# Altium schematic sheet FGM410_485.SchDoc
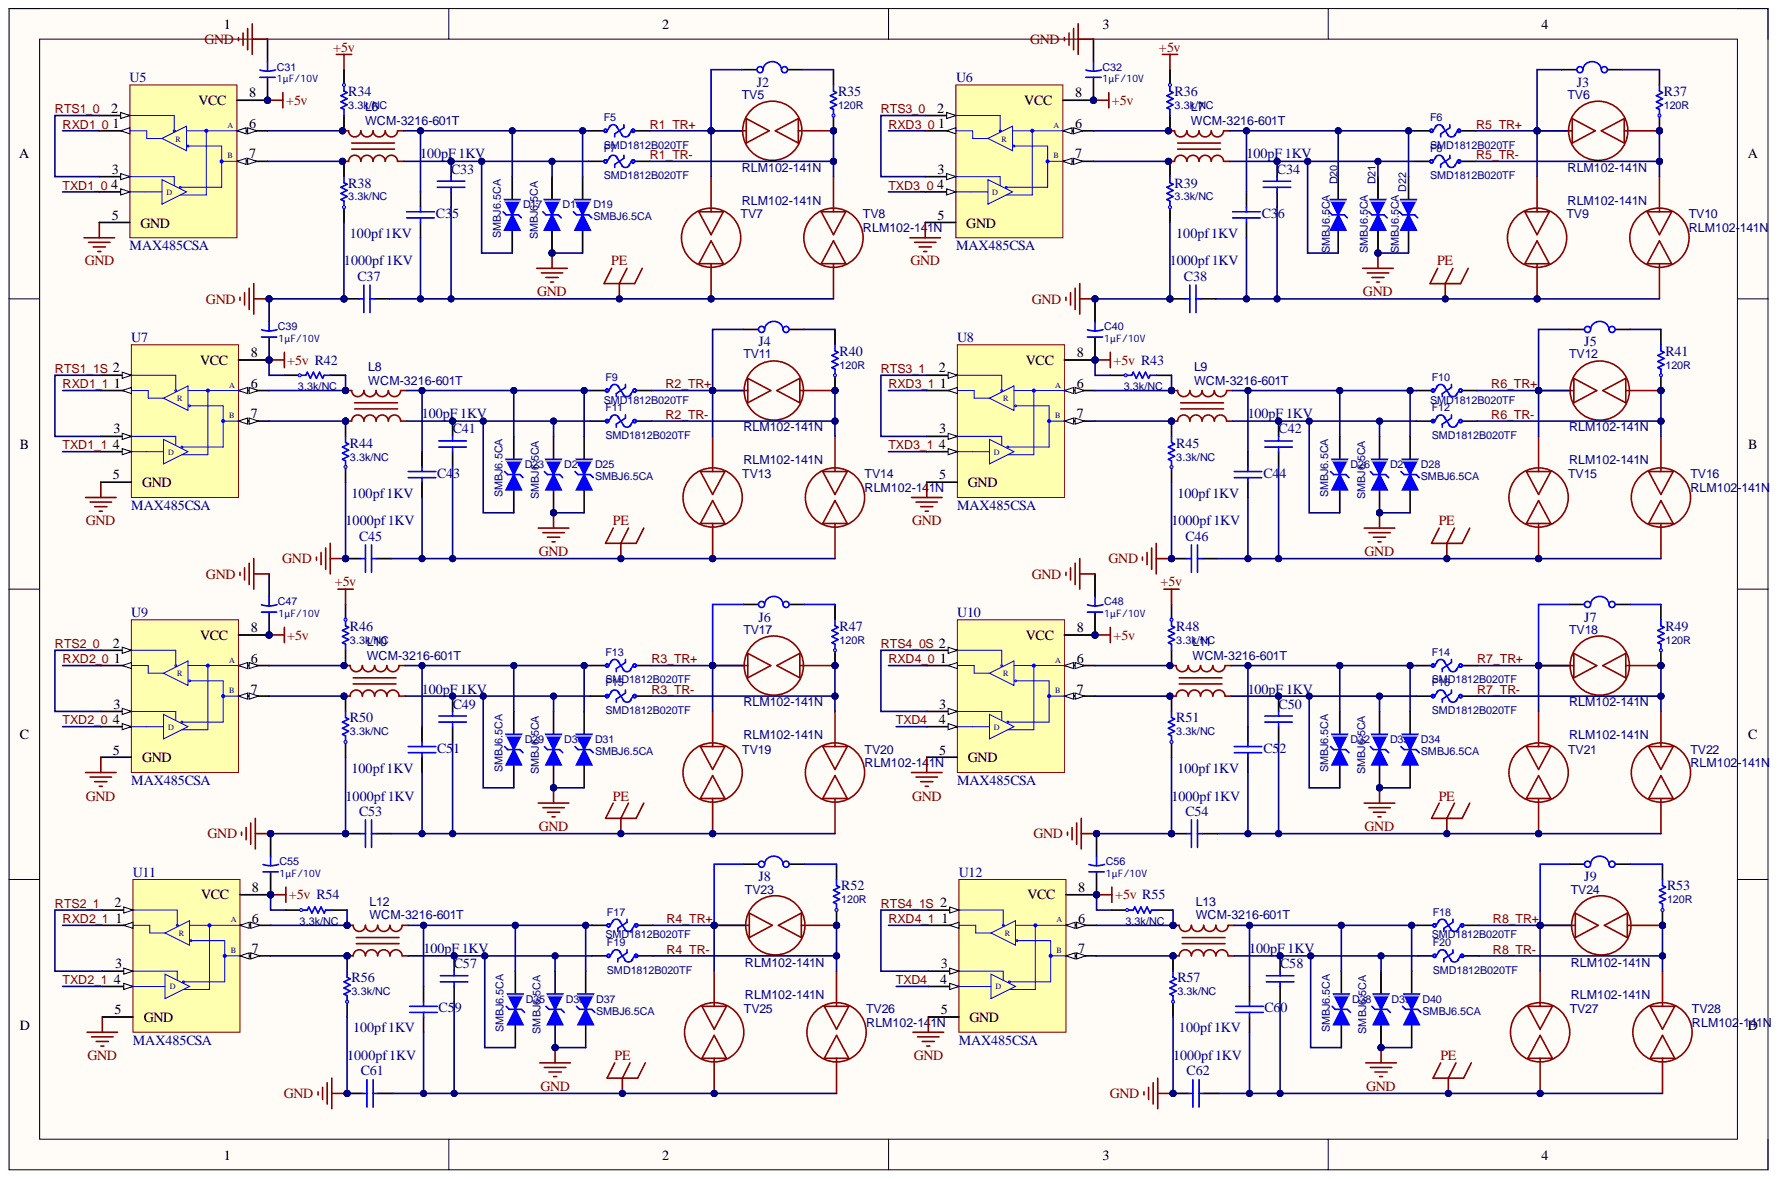
[diagram p0: FGM410_485.SchDoc]

## Parts
C31  1μF/10V  [0603_C]
C32  1μF/10V  [0603_C]
C33  100pF 1KV  [C1206]
C34  100pF 1KV  [C1206]
C35  100pf 1KV  [C1206]
C36  100pf 1KV  [C1206]
C37  1000pf 1KV  [C1206]
C38  1000pf 1KV  [C1206]
C39  1μF/10V  [0603_C]
C40  1μF/10V  [0603_C]
C41  100pF 1KV  [C1206]
C42  100pF 1KV  [C1206]
C43  100pf 1KV  [C1206]
C44  100pf 1KV  [C1206]
C45  1000pf 1KV  [C1206]
C46  1000pf 1KV  [C1206]
C47  1μF/10V  [0603_C]
C48  1μF/10V  [0603_C]
C49  100pF 1KV  [C1206]
C50  100pF 1KV  [C1206]
C51  100pf 1KV  [C1206]
C52  100pf 1KV  [C1206]
C53  1000pf 1KV  [C1206]
C54  1000pf 1KV  [C1206]
C55  1μF/10V  [0603_C]
C56  1μF/10V  [0603_C]
C57  100pF 1KV  [C1206]
C58  100pF 1KV  [C1206]
C59  100pf 1KV  [C1206]
C60  100pf 1KV  [C1206]
C61  1000pf 1KV  [C1206]
C62  1000pf 1KV  [C1206]
D17  SMBJ6.5CA  [SMB]
D18  SMBJ6.5CA  [SMB]
D19  SMBJ6.5CA  [SMB]
D20  SMBJ6.5CA  [SMB]
D21  SMBJ6.5CA  [SMB]
D22  SMBJ6.5CA  [SMB]
D23  SMBJ6.5CA  [SMB]
D24  SMBJ6.5CA  [SMB]
D25  SMBJ6.5CA  [SMB]
D26  SMBJ6.5CA  [SMB]
D27  SMBJ6.5CA  [SMB]
D28  SMBJ6.5CA  [SMB]
D29  SMBJ6.5CA  [SMB]
D30  SMBJ6.5CA  [SMB]
D31  SMBJ6.5CA  [SMB]
D32  SMBJ6.5CA  [SMB]
D33  SMBJ6.5CA  [SMB]
D34  SMBJ6.5CA  [SMB]
D35  SMBJ6.5CA  [SMB]
D36  SMBJ6.5CA  [SMB]
D37  SMBJ6.5CA  [SMB]
D38  SMBJ6.5CA  [SMB]
D39  SMBJ6.5CA  [SMB]
D40  SMBJ6.5CA  [SMB]
F5  SMD1812B020TF  [F1812]
F6  SMD1812B020TF  [F1812]
F7  SMD1812B020TF  [F1812]
F8  SMD1812B020TF  [F1812]
F9  SMD1812B020TF  [F1812]
F10  SMD1812B020TF  [F1812]
F11  SMD1812B020TF  [F1812]
F12  SMD1812B020TF  [F1812]
F13  SMD1812B020TF  [F1812]
F14  SMD1812B020TF  [F1812]
F15  SMD1812B020TF  [F1812]
F16  SMD1812B020TF  [F1812]
F17  SMD1812B020TF  [F1812]
F18  SMD1812B020TF  [F1812]
F19  SMD1812B020TF  [F1812]
F20  SMD1812B020TF  [F1812]
J2  JP  [SIP2-2.54]  (value not drawn)
J3  JP  [SIP2-2.54]  (value not drawn)
J4  JP  [SIP2-2.54]  (value not drawn)
J5  JP  [SIP2-2.54]  (value not drawn)
J6  JP  [SIP2-2.54]  (value not drawn)
J7  JP  [SIP2-2.54]  (value not drawn)
J8  JP  [SIP2-2.54]  (value not drawn)
J9  JP  [SIP2-2.54]  (value not drawn)
L6  WCM-3216-601T  [FILTER-SMD_4P-L3.2-W1.6-BL]
L7  WCM-3216-601T  [FILTER-SMD_4P-L3.2-W1.6-BL]
L8  WCM-3216-601T  [FILTER-SMD_4P-L3.2-W1.6-BL]
L9  WCM-3216-601T  [FILTER-SMD_4P-L3.2-W1.6-BL]
L10  WCM-3216-601T  [FILTER-SMD_4P-L3.2-W1.6-BL]
L11  WCM-3216-601T  [FILTER-SMD_4P-L3.2-W1.6-BL]
L12  WCM-3216-601T  [FILTER-SMD_4P-L3.2-W1.6-BL]
L13  WCM-3216-601T  [FILTER-SMD_4P-L3.2-W1.6-BL]
R34  3.3k/NC  [0603_R]
R35  120R  [0603_R]
R36  3.3k/NC  [0603_R]
R37  120R  [0603_R]
R38  3.3k/NC  [0603_R]
R39  3.3k/NC  [0603_R]
R40  120R  [0603_R]
R41  120R  [0603_R]
R42  3.3k/NC  [0603_R]
R43  3.3k/NC  [0603_R]
R44  3.3k/NC  [0603_R]
R45  3.3k/NC  [0603_R]
R46  3.3k/NC  [0603_R]
R47  120R  [0603_R]
R48  3.3k/NC  [0603_R]
R49  120R  [0603_R]
R50  3.3k/NC  [0603_R]
R51  3.3k/NC  [0603_R]
R52  120R  [0603_R]
R53  120R  [0603_R]
R54  3.3k/NC  [0603_R]
R55  3.3k/NC  [0603_R]
R56  3.3k/NC  [0603_R]
R57  3.3k/NC  [0603_R]
TV5  RLM102-141N  [DIO-DT-SMD_L5.0-W2.8]
TV6  RLM102-141N  [DIO-DT-SMD_L5.0-W2.8]
TV7  RLM102-141N  [DIO-DT-SMD_L5.0-W2.8]
TV8  RLM102-141N  [DIO-DT-SMD_L5.0-W2.8]
TV9  RLM102-141N  [DIO-DT-SMD_L5.0-W2.8]
TV10  RLM102-141N  [DIO-DT-SMD_L5.0-W2.8]
TV11  RLM102-141N  [DIO-DT-SMD_L5.0-W2.8]
TV12  RLM102-141N  [DIO-DT-SMD_L5.0-W2.8]
TV13  RLM102-141N  [DIO-DT-SMD_L5.0-W2.8]
TV14  RLM102-141N  [DIO-DT-SMD_L5.0-W2.8]
TV15  RLM102-141N  [DIO-DT-SMD_L5.0-W2.8]
TV16  RLM102-141N  [DIO-DT-SMD_L5.0-W2.8]
TV17  RLM102-141N  [DIO-DT-SMD_L5.0-W2.8]
TV18  RLM102-141N  [DIO-DT-SMD_L5.0-W2.8]
TV19  RLM102-141N  [DIO-DT-SMD_L5.0-W2.8]
TV20  RLM102-141N  [DIO-DT-SMD_L5.0-W2.8]
TV21  RLM102-141N  [DIO-DT-SMD_L5.0-W2.8]
TV22  RLM102-141N  [DIO-DT-SMD_L5.0-W2.8]
TV23  RLM102-141N  [DIO-DT-SMD_L5.0-W2.8]
TV24  RLM102-141N  [DIO-DT-SMD_L5.0-W2.8]
TV25  RLM102-141N  [DIO-DT-SMD_L5.0-W2.8]
TV26  RLM102-141N  [DIO-DT-SMD_L5.0-W2.8]
TV27  RLM102-141N  [DIO-DT-SMD_L5.0-W2.8]
TV28  RLM102-141N  [DIO-DT-SMD_L5.0-W2.8]
U5  MAX485CSA  [SOP8]
U6  MAX485CSA  [SOP8]
U7  MAX485CSA  [SOP8]
U8  MAX485CSA  [SOP8]
U9  MAX485CSA  [SOP8]
U10  MAX485CSA  [SOP8]
U11  MAX485CSA  [SOP8]
U12  MAX485CSA  [SOP8]

## Nets
+5v: C31.1 C32.1 C39.1 C40.1 C47.1 C48.1 C55.1 C56.1 R34.1 R36.1 R42.1 R43.1 R46.1 R48.1 R54.1 R55.1 U5.8(VCC) U6.8(VCC) U7.8(VCC) U8.8(VCC) U9.8(VCC) U10.8(VCC) U11.8(VCC) U12.8(VCC)
GND: C31.2 C32.2 C37.1 C38.1 C39.2 C40.2 C45.1 C46.1 C47.2 C48.2 C53.1 C54.1 C55.2 C56.2 C61.1 C62.1 D18.1 D19.1 D21.1 D22.1 D24.1 D25.1 D27.1 D28.1 D30.1 D31.1 D33.1 D34.1 D36.1 D37.1 D39.1 D40.1 R38.1 R39.1 R44.1 R45.1 R50.1 R51.1 R56.1 R57.1 U5.5(GND) U6.5(GND) U7.5(GND) U8.5(GND) U9.5(GND) U10.5(GND) U11.5(GND) U12.5(GND)
PE: C33.2 C34.2 C35.2 C36.2 C37.2 C38.2 C41.2 C42.2 C43.2 C44.2 C45.2 C46.2 C49.2 C50.2 C51.2 C52.2 C53.2 C54.2 C57.2 C58.2 C59.2 C60.2 C61.2 C62.2 TV7.1 TV8.1 TV9.1 TV10.1 TV13.1 TV14.1 TV15.1 TV16.1 TV19.1 TV20.1 TV21.1 TV22.1 TV25.1 TV26.1 TV27.1 TV28.1
R1_TR+: F5.2 J2.1 TV5.2 TV7.2
R1_TR-: F7.2 R35.1 TV5.1 TV8.2
R2_TR+: F9.2 J4.1 TV11.2 TV13.2
R2_TR-: F11.2 R40.1 TV11.1 TV14.2
R3_TR+: F13.2 J6.1 TV17.2 TV19.2
R3_TR-: F15.2 R47.1 TV17.1 TV20.2
R4_TR+: F17.2 J8.1 TV23.2 TV25.2
R4_TR-: F19.2 R52.1 TV23.1 TV26.2
R5_TR+: F6.2 J3.1 TV6.2 TV9.2
R5_TR-: F8.2 R37.1 TV6.1 TV10.2
R6_TR+: F10.2 J5.1 TV12.2 TV15.2
R6_TR-: F12.2 R41.1 TV12.1 TV16.2
R7_TR+: F14.2 J7.1 TV18.2 TV21.2
R7_TR-: F16.2 R49.1 TV18.1 TV22.2
R8_TR+: F18.2 J9.1 TV24.2 TV27.2
R8_TR-: F20.2 R53.1 TV24.1 TV28.2
RTS1_0: U5.2 U5.3
RTS1_1S: U7.2 U7.3
RTS2_0: U9.2 U9.3
RTS2_1: U11.2 U11.3
RTS3_0: U6.2 U6.3
RTS3_1: U8.2 U8.3
RTS4_0S: U10.2 U10.3
RTS4_1S: U12.2 U12.3
RXD1_0: U5.1
RXD1_1: U7.1
RXD2_0: U9.1
RXD2_1: U11.1
RXD3_0: U6.1
RXD3_1: U8.1
RXD4_0: U10.1
RXD4_1: U12.1
TXD1_0: U5.4
TXD1_1: U7.4
TXD2_0: U9.4
TXD2_1: U11.4
TXD3_0: U6.4
TXD3_1: U8.4
TXD4: U10.4 U12.4
NetC33_1: C33.1 D17.1 D18.2 F7.1 L6.3
NetC34_1: C34.1 D20.1 D21.2 F8.1 L7.3
NetC35_1: C35.1 D17.2 D19.2 F5.1 L6.4
NetC36_1: C36.1 D20.2 D22.2 F6.1 L7.4
NetC41_1: C41.1 D23.1 D24.2 F11.1 L8.3
NetC42_1: C42.1 D26.1 D27.2 F12.1 L9.3
NetC43_1: C43.1 D23.2 D25.2 F9.1 L8.4
NetC44_1: C44.1 D26.2 D28.2 F10.1 L9.4
NetC49_1: C49.1 D29.1 D30.2 F15.1 L10.3
NetC50_1: C50.1 D32.1 D33.2 F16.1 L11.3
NetC51_1: C51.1 D29.2 D31.2 F13.1 L10.4
NetC52_1: C52.1 D32.2 D34.2 F14.1 L11.4
NetC57_1: C57.1 D35.1 D36.2 F19.1 L12.3
NetC58_1: C58.1 D38.1 D39.2 F20.1 L13.3
NetC59_1: C59.1 D35.2 D37.2 F17.1 L12.4
NetC60_1: C60.1 D38.2 D40.2 F18.1 L13.4
NetJ2_2: J2.2 R35.2
NetJ3_2: J3.2 R37.2
NetJ4_2: J4.2 R40.2
NetJ5_2: J5.2 R41.2
NetJ6_2: J6.2 R47.2
NetJ7_2: J7.2 R49.2
NetJ8_2: J8.2 R52.2
NetJ9_2: J9.2 R53.2
NetL6_1: L6.1 R34.2 U5.6
NetL6_2: L6.2 R38.2 U5.7
NetL7_1: L7.1 R36.2 U6.6
NetL7_2: L7.2 R39.2 U6.7
NetL8_1: L8.1 R42.2 U7.6
NetL8_2: L8.2 R44.2 U7.7
NetL9_1: L9.1 R43.2 U8.6
NetL9_2: L9.2 R45.2 U8.7
NetL10_1: L10.1 R46.2 U9.6
NetL10_2: L10.2 R50.2 U9.7
NetL11_1: L11.1 R48.2 U10.6
NetL11_2: L11.2 R51.2 U10.7
NetL12_1: L12.1 R54.2 U11.6
NetL12_2: L12.2 R56.2 U11.7
NetL13_1: L13.1 R55.2 U12.6
NetL13_2: L13.2 R57.2 U12.7

## Library data of the parts
C31 C32 C39 C40 C47 C48 C55 C56 = Cap: Capacitor; Component Kind: Standard; Published: 23-Sep-2002; Signal Integrity: Cap; Simulation: CAP; Value: 1μF/10V; Library Name: zzt.SchLib; Publisher: Altium Limited
C33-C38 C41-C46 C49-C54 C57-C62 = CAP: Capacitor; Component Kind: Standard; Library Name: 杂元件库.SCHLIB
D17-D40 = TVS: Code_JEDEC: DO-214-AB; Component Kind: Standard; Description: TVS; LatestRevisionDate: 17-Jul-2002; LatestRevisionNote: Re-released for DXP Platform.; Published: 8-Jun-2000; Signal Integrity: Diode; Simulation: DIODE; Library Name: zzt.SchLib; Publisher: Altium Limited
F5-F20 = Fuse Thermal: Thermal Fuse; Component Kind: Standard; LatestRevisionDate: 17-Jul-2002; LatestRevisionNote: Re-released for DXP Platform.; PackageReference: PIN-W2/E2.8; Published: 24-Mar-1999; Library Name: zzt.SchLib; Publisher: Altium Limited
J2-J9 = JMP: Component Kind: Standard; Library Name: My_Int_Library.IntLib
L6-L13 = PCAQ1211FA-202: BOM_Manufacturer: PROD Tech; Contributor: LCSC; BOM_Manufacturer Part: PCAQ1211FA-202; BOM_Supplier: LCSC; BOM_Supplier Part: C784889; Component Kind: Standard; spicePre: L; spiceSymbolName: PCAQ1211FA-202
R34-R57 = Res: Resistor; Component Kind: Standard; LatestRevisionDate: 17-Jul-2002; LatestRevisionNote: Re-released for DXP Platform.; Published: 8-Jun-2000; Signal Integrity: Res; Simulation: RESISTOR; Library Name: zzt.SchLib; Publisher: Altium Limited
  R34 R36 R38 R39 R42-R46 R48 R50 R51 R54-R57: Value: 3.3k/NC
  R35 R37 R40 R41 R47 R49 R52 R53: Value: 120R
TV5-TV28 = 4532-091-LF: BOM_Manufacturer: Brightking; BOM_JLCPCB Part Class: Extended Part; BOM_Manufacturer Part: 4532-091-LF; BOM_Supplier: LCSC; BOM_Supplier Part: C78323; Component Kind: Standard; spicePre: T; spiceSymbolName: 4532-091-LF
U5-U12 = MAX485CSA: Low-Power, Slew-Rate-Limited RS-485/RS-422 Transceiver; Code_IPC: SOIC127P600-8; Code_JEDEC: MS-012-AA; Component Kind: Standard; ComponentLink1Description: Manufacturer Link; ComponentLink1URL: http://www.maxim-ic.com/; ComponentLink2Description: Datasheet; ComponentLink2URL: http://pdfserv.maxim-ic.com/en/ds/MAX1487-MAX491.pdf; DatasheetVersion: Feb-1996; LatestRevisionDate: 13-Apr-2006; LatestRevisionNote: IPC-7351 Footprint Added.; Library Name: Maxim Communication Transceiver.IntLib; PackageDescription: 8-Pin Small Outline Integrated Circuit 1.27 mm Pitch; PackageReference: NSO8; PCB3D: NSO8; Published: 16-Jan-2001; Publisher: Altium Limited
